# Altium schematic sheet EntradaCTLB50.SchDoc
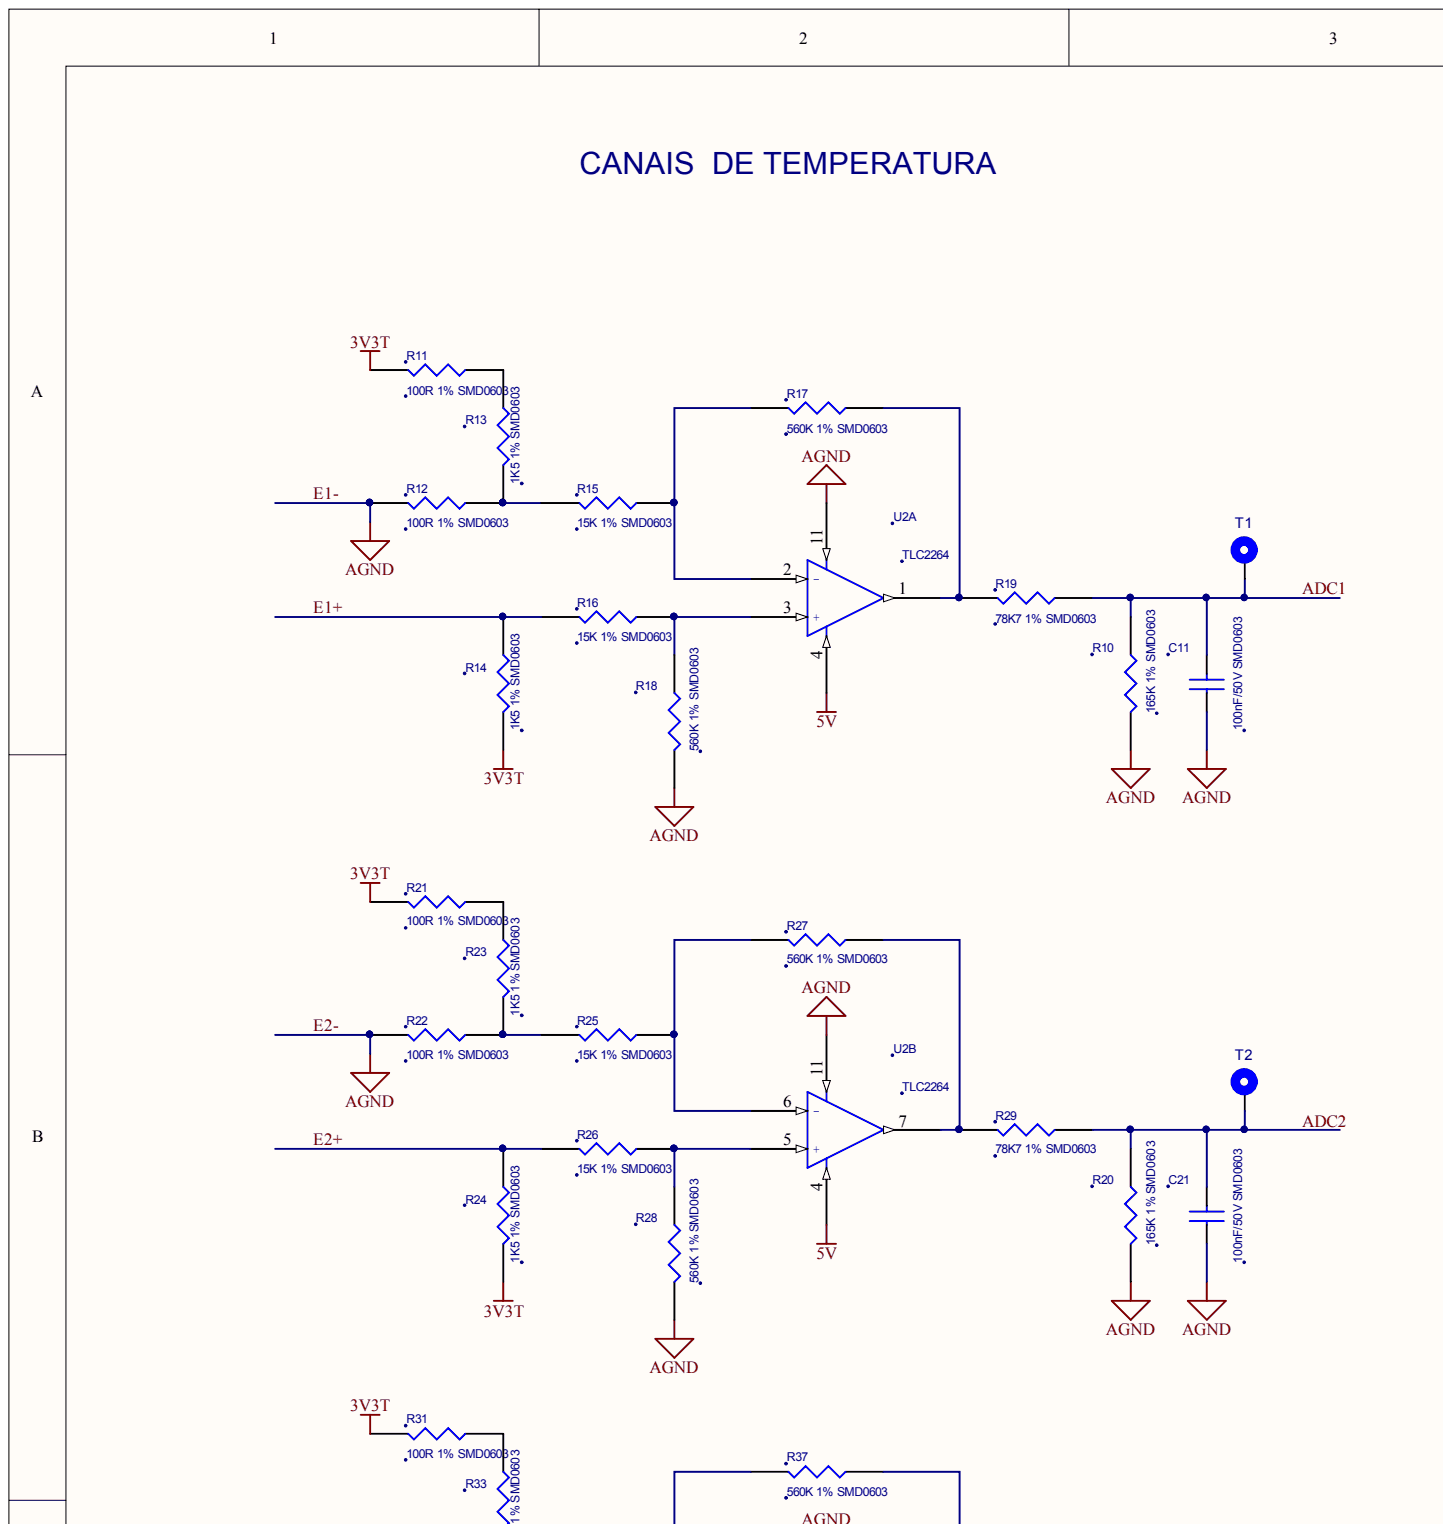
[diagram p0: EntradaCTLB50.SchDoc, top left]
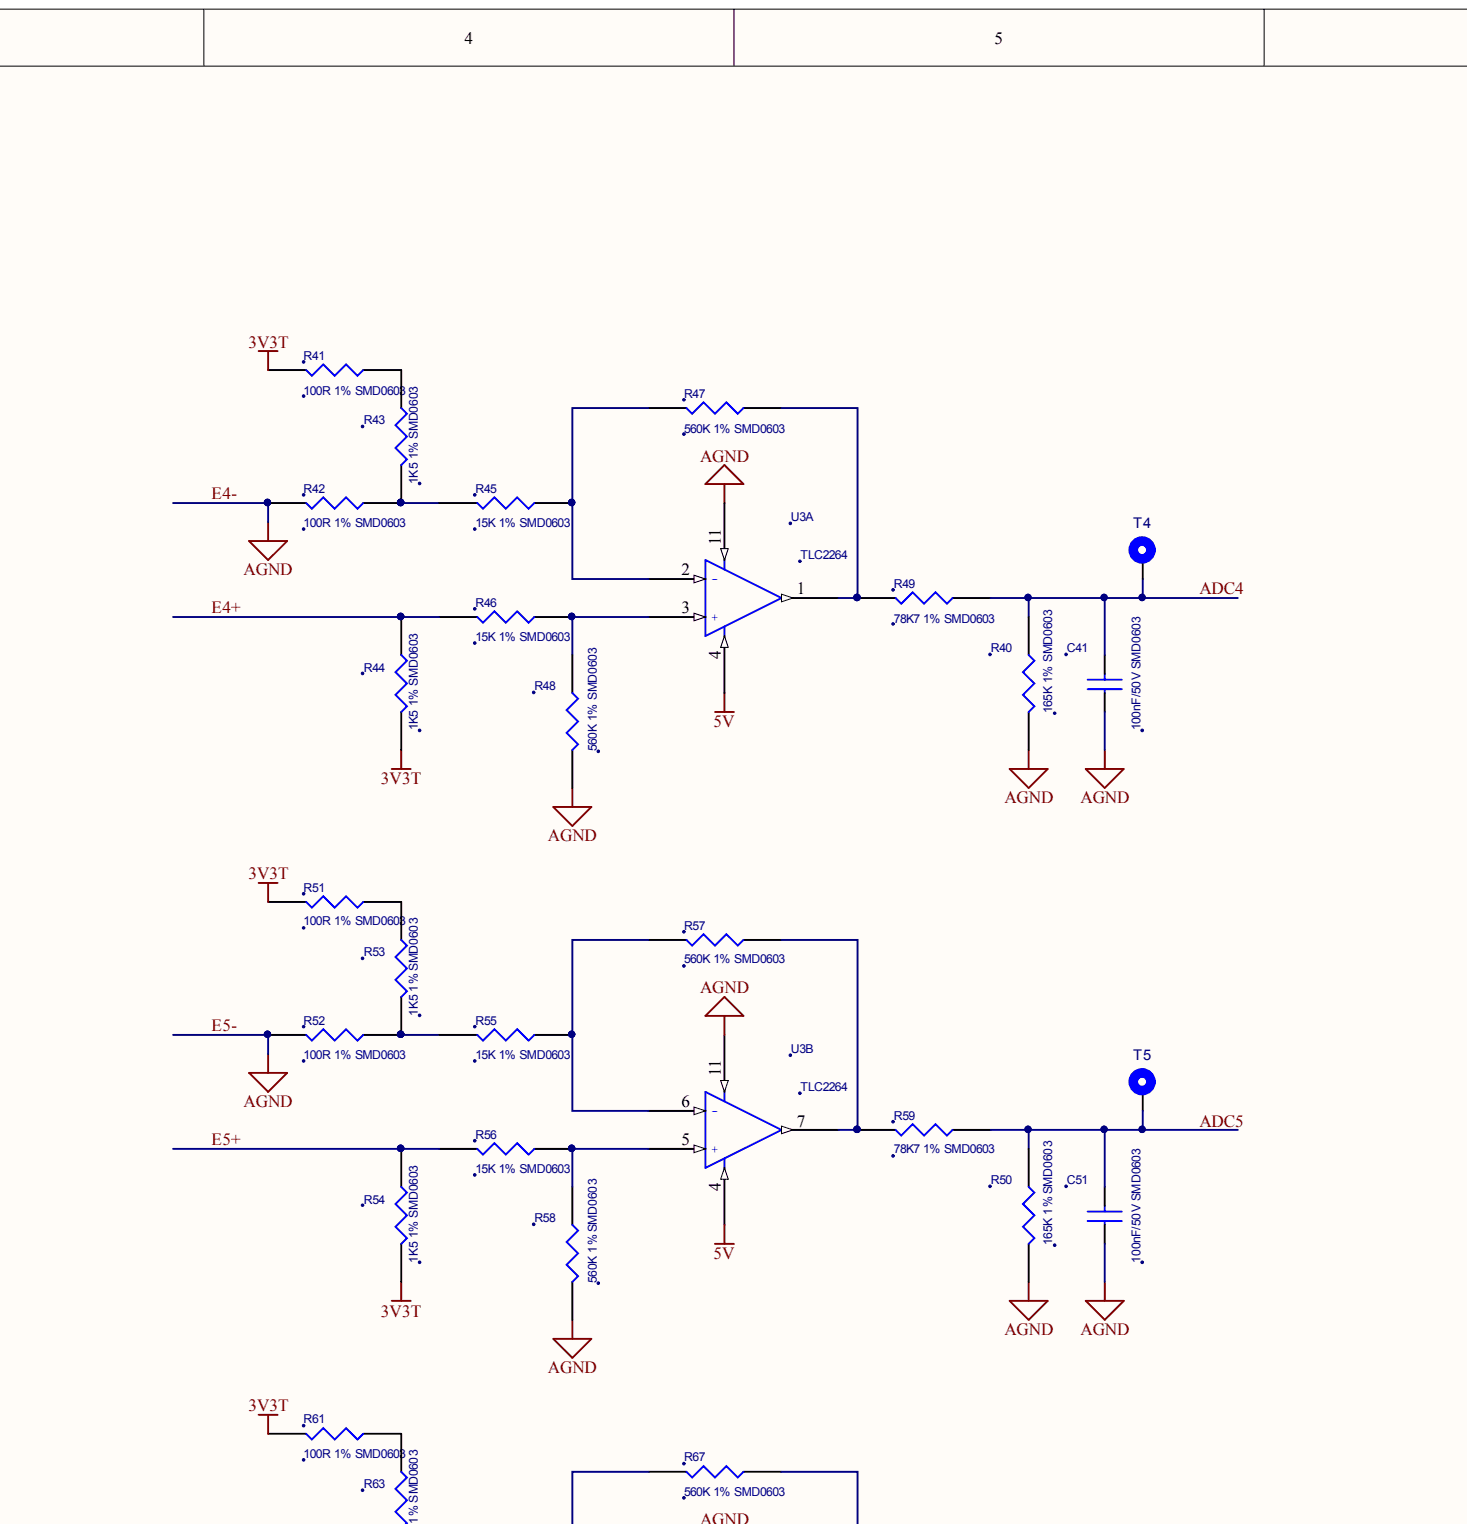
[diagram p1: EntradaCTLB50.SchDoc, top centre]
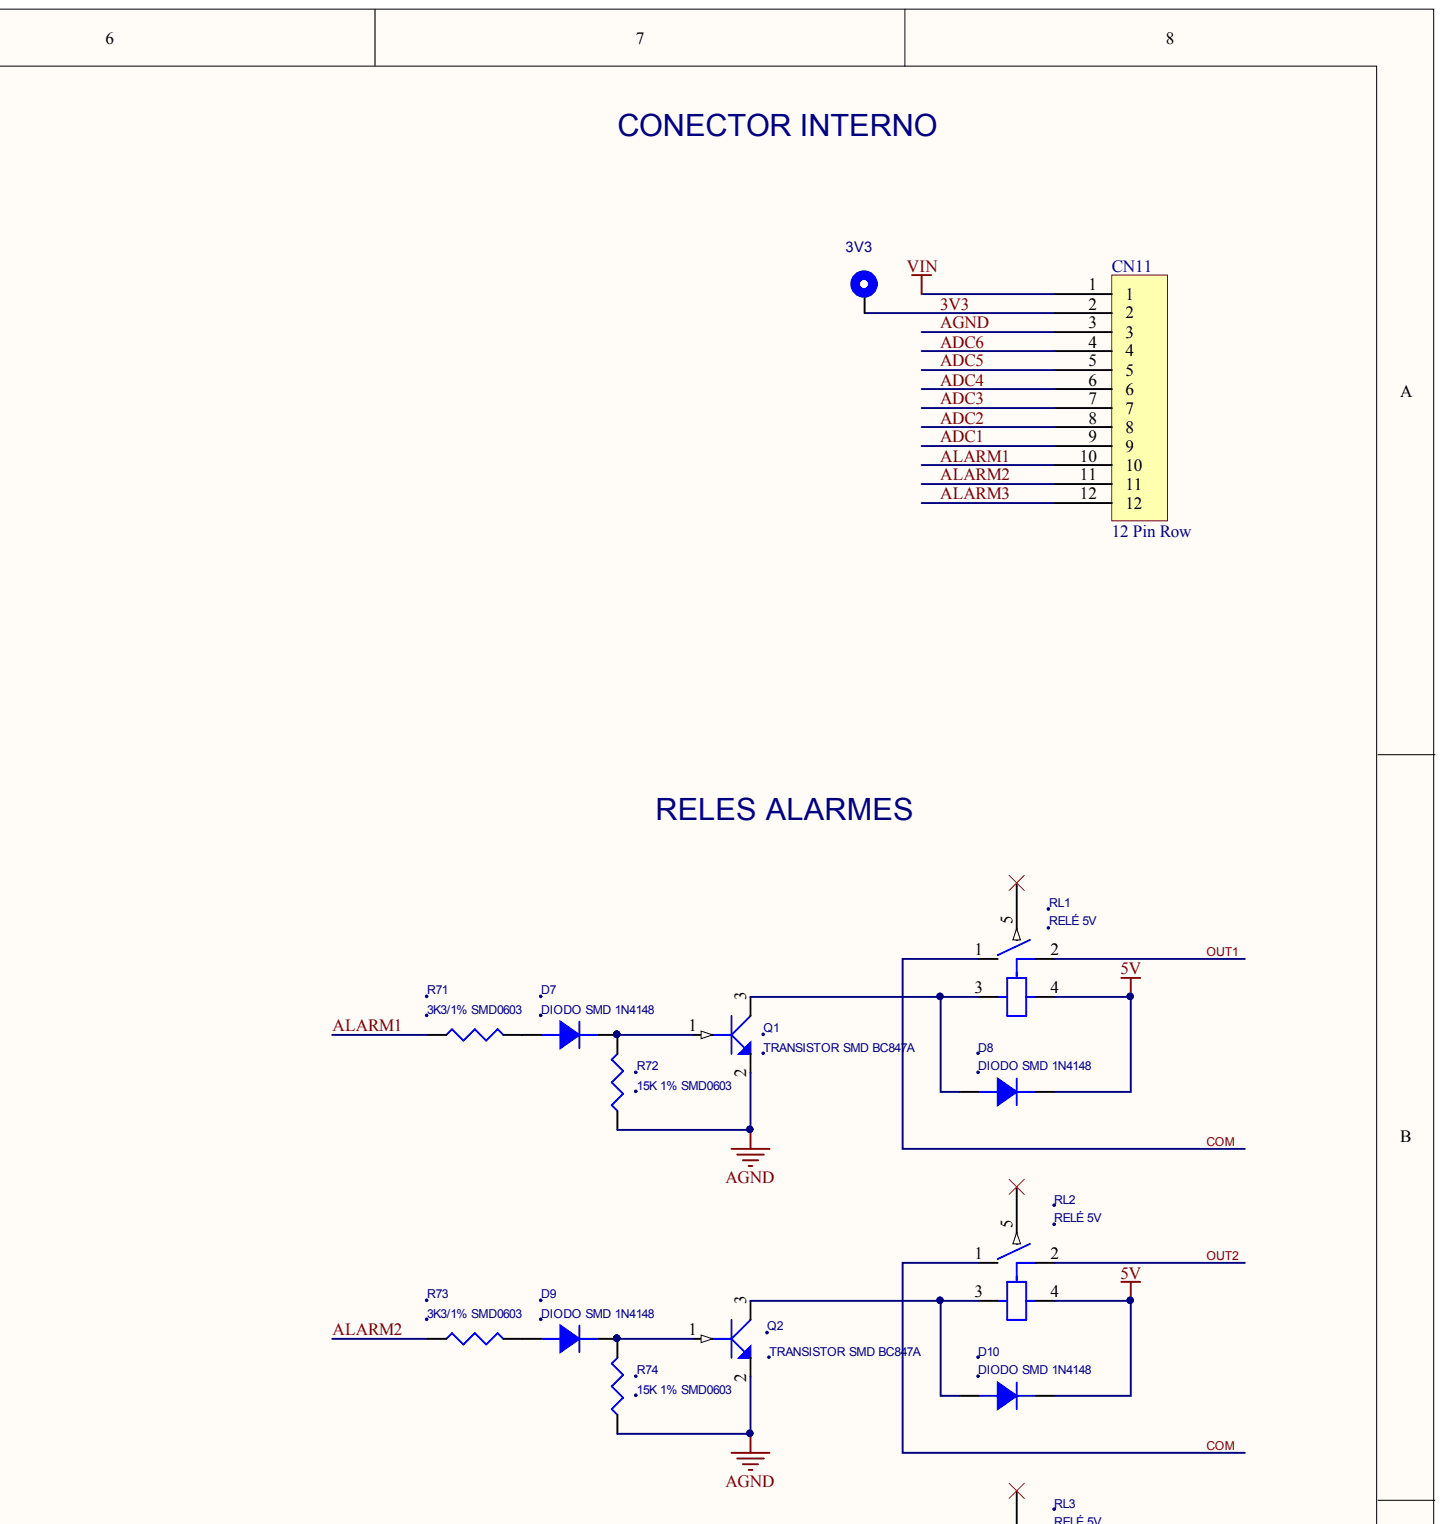
[diagram p2: EntradaCTLB50.SchDoc, top right]
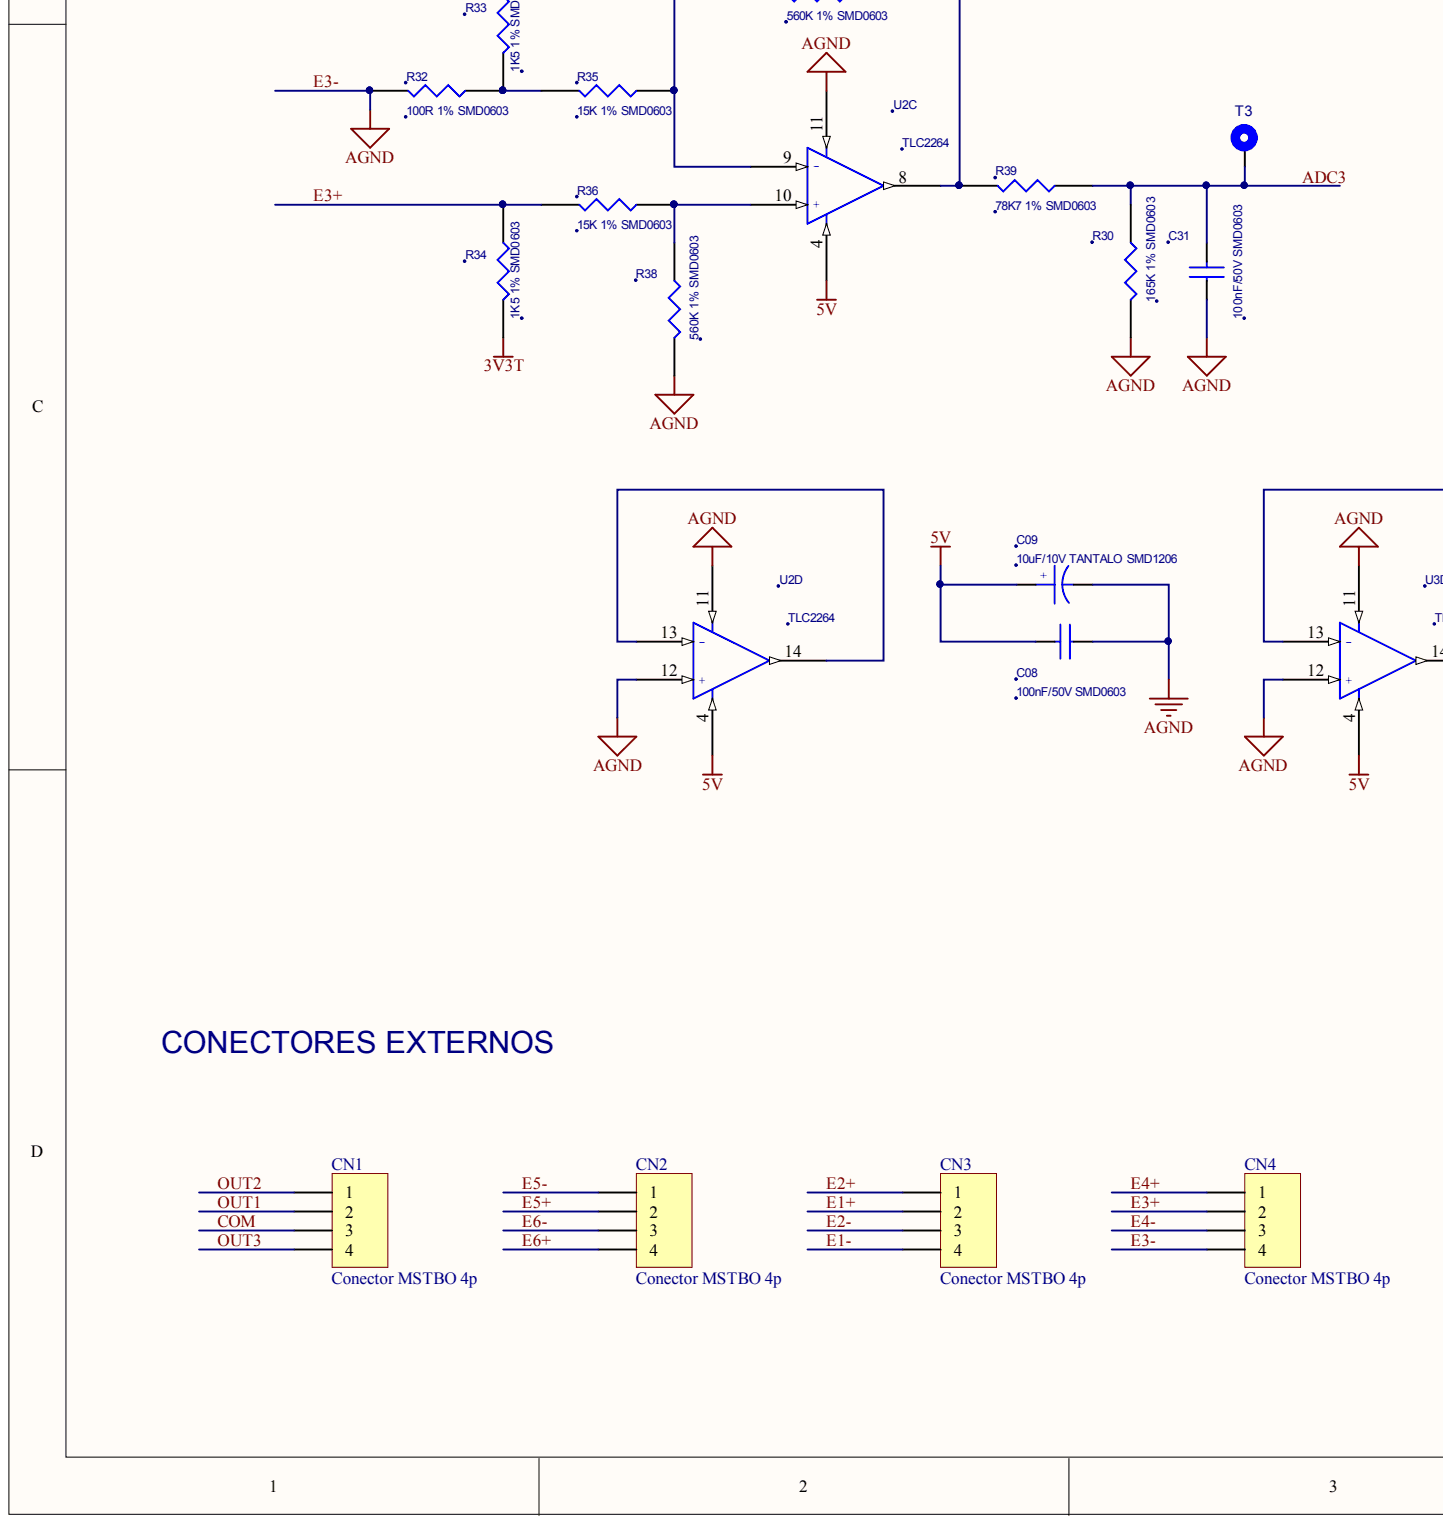
[diagram p3: EntradaCTLB50.SchDoc, bottom left]
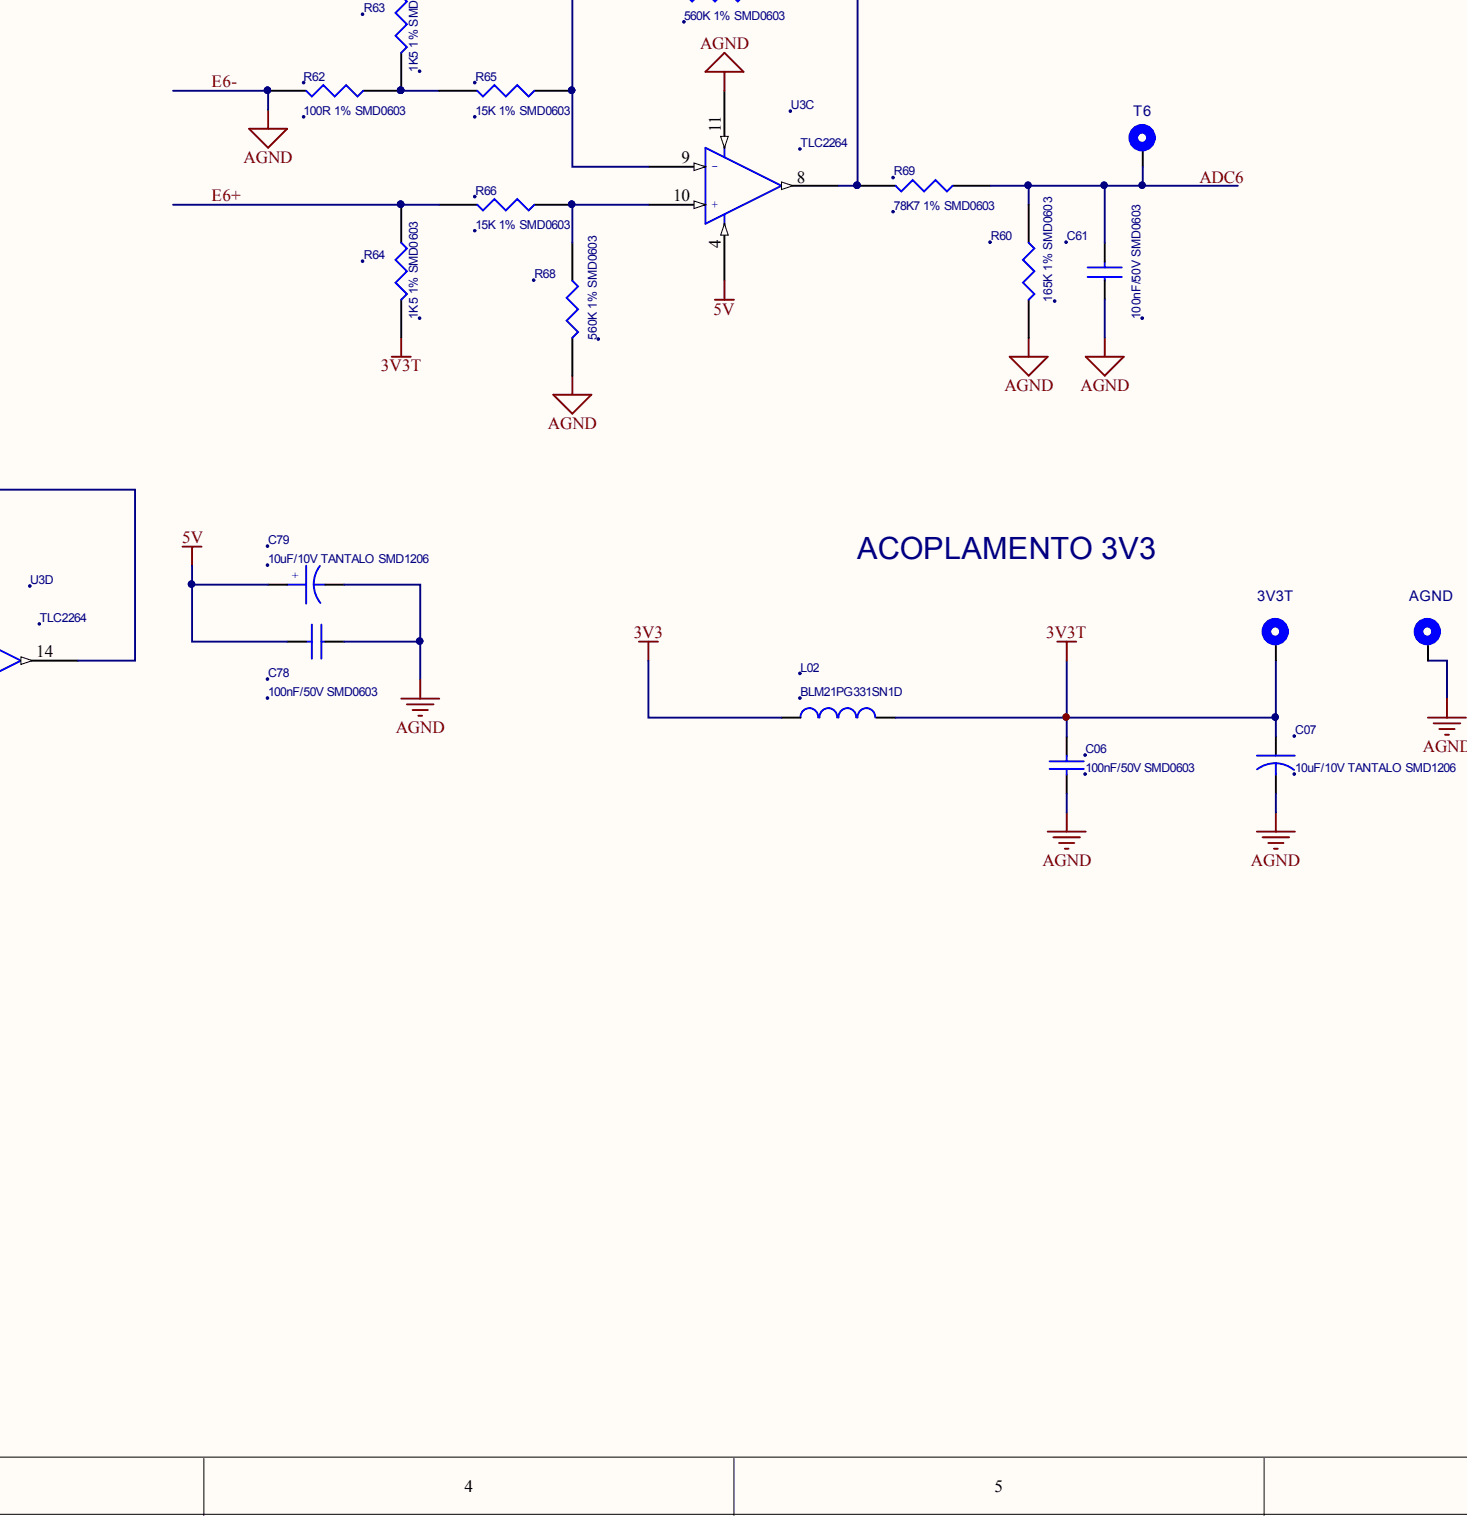
[diagram p4: EntradaCTLB50.SchDoc, bottom centre]
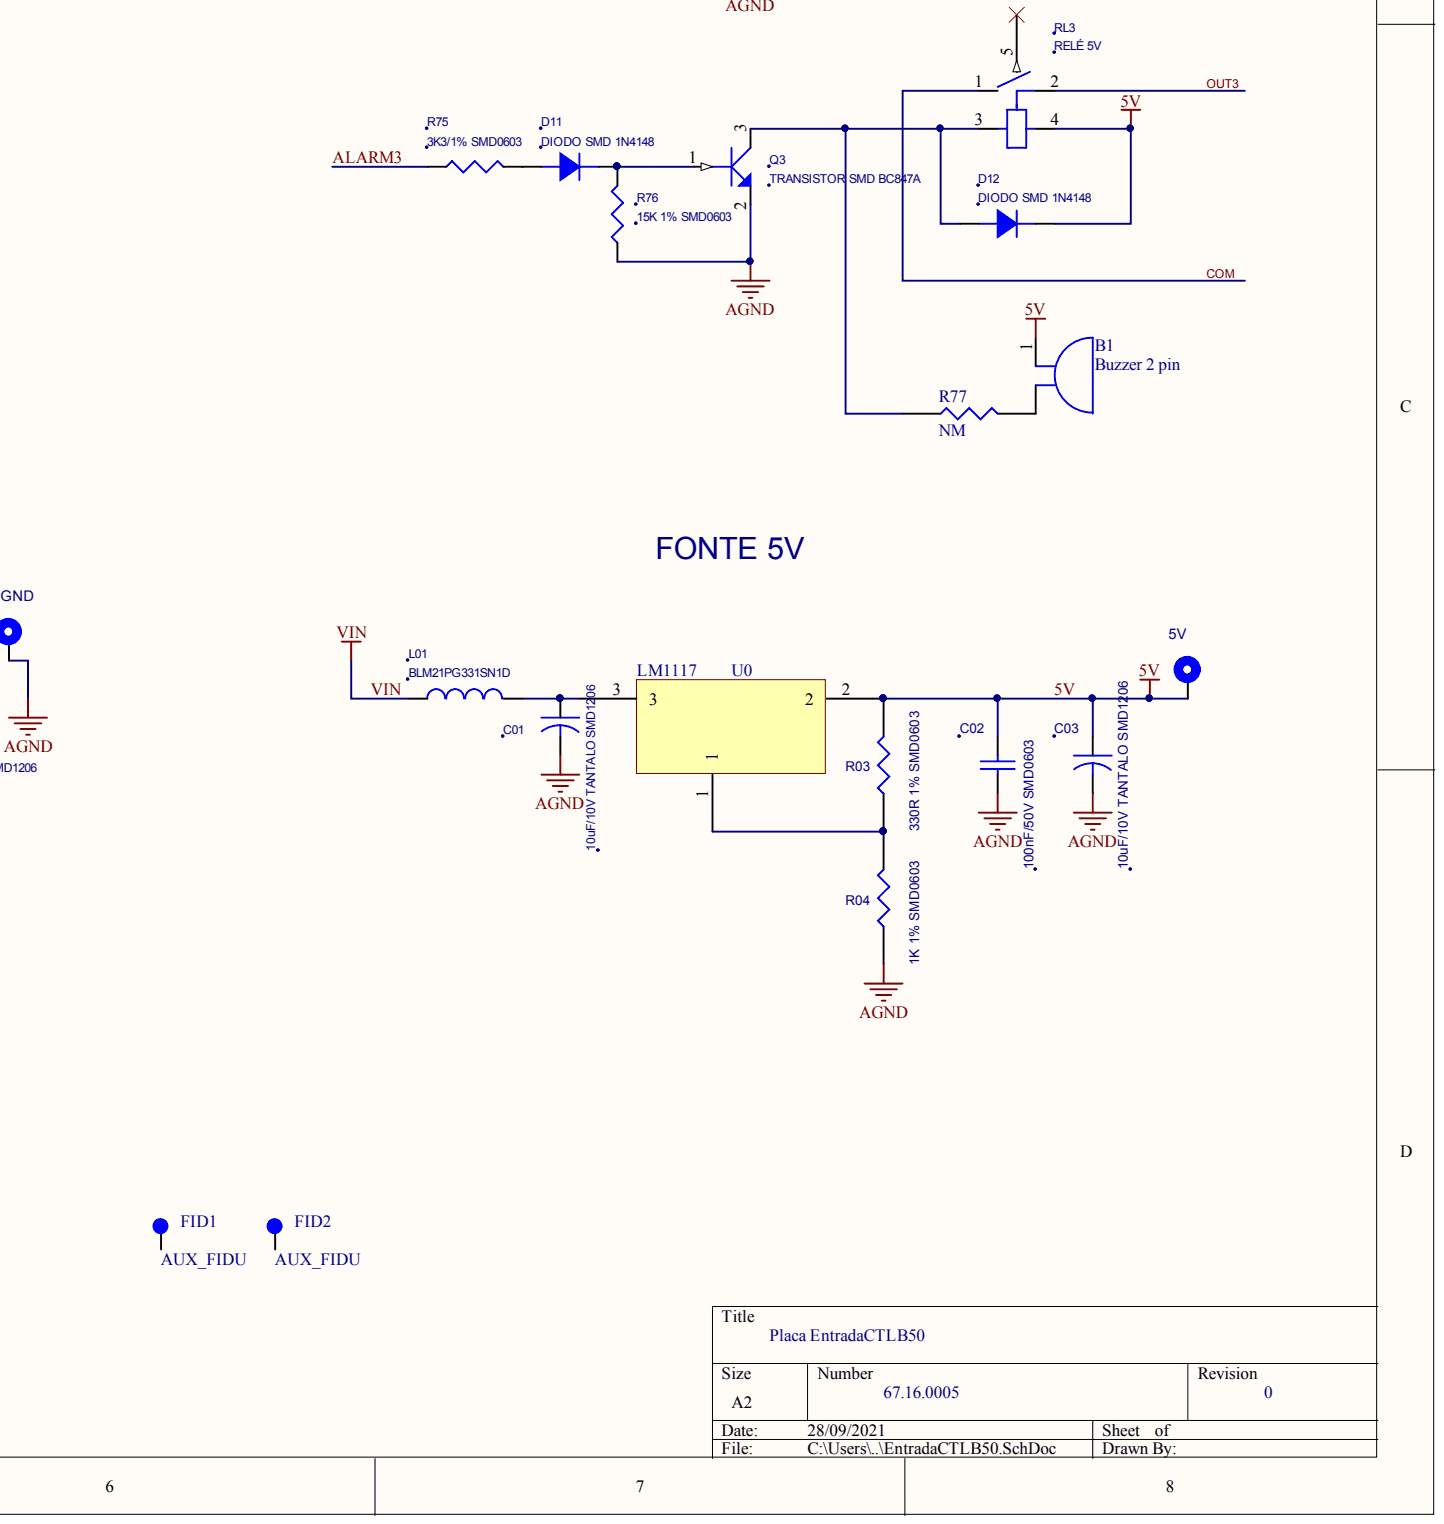
[diagram p5: EntradaCTLB50.SchDoc, bottom right]

## Parts
3V3  PT  [PTR31]  (value not drawn)
3V3T  PT  [PTR31]  (value not drawn)
5V  PT  [PTR31]  (value not drawn)
AGND  PT  [PTR31]  (value not drawn)
B1  Buzzer 2 pin  [Buzzer 5V d20mm]
C01  10uF/10V TANTALO SMD1206  [TANA]
C02  100nF/50V SMD0603  [0603]
C03  10uF/10V TANTALO SMD1206  [TANA]
C06  100nF/50V SMD0603  [0603]
C07  10uF/10V TANTALO SMD1206  [TANA]
C08  100nF/50V SMD0603  [0603]
C09  10uF/10V TANTALO SMD1206  [TANA]
C11  100nF/50V SMD0603  [0603]
C21  100nF/50V SMD0603  [0603]
C31  100nF/50V SMD0603  [0603]
C41  100nF/50V SMD0603  [0603]
C51  100nF/50V SMD0603  [0603]
C61  100nF/50V SMD0603  [0603]
C78  100nF/50V SMD0603  [0603]
C79  10uF/10V TANTALO SMD1206  [TANA]
CN1  Conector MSTBO 4p  [MSTBO-DIR]
CN2  Conector MSTBO 4p  [MSTBO-DIR]
CN3  Conector MSTBO 4p  [MSTBO-ESQ]
CN4  Conector MSTBO 4p  [MSTBO-ESQ]
CN11  12 Pin Row  [12 Pin Row]
D7  DIODO SMD 1N4148  [DIODOSMD]
D8  DIODO SMD 1N4148  [DIODOSMD]
D9  DIODO SMD 1N4148  [DIODOSMD]
D10  DIODO SMD 1N4148  [DIODOSMD]
D11  DIODO SMD 1N4148  [DIODOSMD]
D12  DIODO SMD 1N4148  [DIODOSMD]
FID1  AUX_FIDU  [AUX_FIDUCIAL]
FID2  AUX_FIDU  [AUX_FIDUCIAL]
L01  BLM21PG331SN1D  [BLM21]
L02  BLM21PG331SN1D  [BLM21]
Q1  TRANSISTOR SMD BC847A  [BC847A]
Q2  TRANSISTOR SMD BC847A  [bc847a]
Q3  TRANSISTOR SMD BC847A  [bc847a]
R03  330R 1% SMD0603  [0603]
R04  1K 1% SMD0603  [0603]
R10  165K 1% SMD0603  [0603]
R11  100R 1% SMD0603  [0603]
R12  100R 1% SMD0603  [0603]
R13  1K5 1% SMD0603  [0603]
R14  1K5 1% SMD0603  [0603]
R15  15K 1% SMD0603  [0603]
R16  15K 1% SMD0603  [0603]
R17  560K 1% SMD0603  [0603]
R18  560K 1% SMD0603  [0603]
R19  78K7 1% SMD0603  [0603]
R20  165K 1% SMD0603  [0603]
R21  100R 1% SMD0603  [0603]
R22  100R 1% SMD0603  [0603]
R23  1K5 1% SMD0603  [0603]
R24  1K5 1% SMD0603  [0603]
R25  15K 1% SMD0603  [0603]
R26  15K 1% SMD0603  [0603]
R27  560K 1% SMD0603  [0603]
R28  560K 1% SMD0603  [0603]
R29  78K7 1% SMD0603  [0603]
R30  165K 1% SMD0603  [0603]
R31  100R 1% SMD0603  [0603]
R32  100R 1% SMD0603  [0603]
R33  1K5 1% SMD0603  [0603]
R34  1K5 1% SMD0603  [0603]
R35  15K 1% SMD0603  [0603]
R36  15K 1% SMD0603  [0603]
R37  560K 1% SMD0603  [0603]
R38  560K 1% SMD0603  [0603]
R39  78K7 1% SMD0603  [0603]
R40  165K 1% SMD0603  [0603]
R41  100R 1% SMD0603  [0603]
R42  100R 1% SMD0603  [0603]
R43  1K5 1% SMD0603  [0603]
R44  1K5 1% SMD0603  [0603]
R45  15K 1% SMD0603  [0603]
R46  15K 1% SMD0603  [0603]
R47  560K 1% SMD0603  [0603]
R48  560K 1% SMD0603  [0603]
R49  78K7 1% SMD0603  [0603]
R50  165K 1% SMD0603  [0603]
R51  100R 1% SMD0603  [0603]
R52  100R 1% SMD0603  [0603]
R53  1K5 1% SMD0603  [0603]
R54  1K5 1% SMD0603  [0603]
R55  15K 1% SMD0603  [0603]
R56  15K 1% SMD0603  [0603]
R57  560K 1% SMD0603  [0603]
R58  560K 1% SMD0603  [0603]
R59  78K7 1% SMD0603  [0603]
R60  165K 1% SMD0603  [0603]
R61  100R 1% SMD0603  [0603]
R62  100R 1% SMD0603  [0603]
R63  1K5 1% SMD0603  [0603]
R64  1K5 1% SMD0603  [0603]
R65  15K 1% SMD0603  [0603]
R66  15K 1% SMD0603  [0603]
R67  560K 1% SMD0603  [0603]
R68  560K 1% SMD0603  [0603]
R69  78K7 1% SMD0603  [0603]
R71  3K3/1% SMD0603  [C0603]
R72  15K 1% SMD0603  [C0603]
R73  3K3/1% SMD0603  [C0603]
R74  15K 1% SMD0603  [C0603]
R75  3K3/1% SMD0603  [C0603]
R76  15K 1% SMD0603  [C0603]
R77  NM  [0603]
RL1  RELÉ 5V  [RELE2]
RL2  RELÉ 5V  [RELE2]
RL3  RELÉ 5V  [RELE2]
T1  PT  [PTSMD60]  (value not drawn)
T2  PT  [PTSMD60]  (value not drawn)
T3  PT  [PTSMD60]  (value not drawn)
T4  PT  [PTSMD60]  (value not drawn)
T5  PT  [PTSMD60]  (value not drawn)
T6  PT  [PTSMD60]  (value not drawn)
U0  LM1117  [SOT223G]
U2  TLC2264  [SOIC14]  (parts A,B,C,D)
U3  TLC2264  [SOIC14]  (parts A,B,C,D)

## Nets
3V3T: 3V3T.1 C06.1 C07.1 L02.2 R11.2 R14.2 R21.2 R24.2 R31.2 R34.2 R41.2 R44.2 R51.2 R54.2 R61.2 R64.2
3V3: 3V3.1 CN11.2 L02.1
5V: 5V.1 B1.1 C02.1 C03.1 C08.2 C09.1 C78.2 C79.1 D8.2 D10.2 D12.2 R03.2 RL1.4 RL2.4 RL3.4 U0.2 U2.4(5V) U3.4(5V)
ADC1: C11.2 CN11.9 R10.1 R19.1 T1.1
ADC2: C21.2 CN11.8 R20.1 R29.1 T2.1
ADC3: C31.2 CN11.7 R30.1 R39.1 T3.1
ADC4: C41.2 CN11.6 R40.1 R49.1 T4.1
ADC5: C51.2 CN11.5 R50.1 R59.1 T5.1
ADC6: C61.2 CN11.4 R60.1 R69.1 T6.1
AGND: AGND.1 C01.2 C02.2 C03.2 C06.2 C07.2 C08.1 C09.2 C11.1 C21.1 C31.1 C41.1 C51.1 C61.1 C78.1 C79.2 CN2.1 CN2.3 CN3.3 CN3.4 CN4.3 CN4.4 CN11.3 Q1.2 Q2.2 Q3.2 R04.1 R10.2 R12.2 R18.2 R20.2 R22.2 R28.2 R30.2 R32.2 R38.2 R40.2 R42.2 R48.2 R50.2 R52.2 R58.2 R60.2 R62.2 R68.2 R72.1 R74.1 R76.1 U2.11 U2.12(+) U3.11 U3.12(+)
ALARM1: CN11.10 R71.1
ALARM2: CN11.11 R73.1
ALARM3: CN11.12 R75.1
COM: CN1.3 RL1.1 RL2.1 RL3.1
E1+: CN3.2 R14.1 R16.2
E2+: CN3.1 R24.1 R26.2
E3+: CN4.2 R34.1 R36.2
E4+: CN4.1 R44.1 R46.2
E5+: CN2.2 R54.1 R56.2
E6+: CN2.4 R64.1 R66.2
OUT1: CN1.2 RL1.2
OUT2: CN1.1 RL2.2
OUT3: CN1.4 RL3.2
VIN: CN11.1 L01.1
NetB1_2: B1.2 R77.2
NetC01_1: C01.1 L01.2 U0.3
NetD7_1: D7.1 R71.2
NetD7_2: D7.2 Q1.1 R72.2
NetD8_1: D8.1 Q1.3 RL1.3
NetD9_1: D9.1 R73.2
NetD9_2: D9.2 Q2.1 R74.2
NetD10_1: D10.1 Q2.3 RL2.3
NetD11_1: D11.1 R75.2
NetD11_2: D11.2 Q3.1 R76.2
NetD12_1: D12.1 Q3.3 R77.1 RL3.3
NetR03_1: R03.1 R04.2 U0.1
NetR11_1: R11.1 R13.1
NetR12_1: R12.1 R13.2 R15.2
NetR15_1: R15.1 R17.2 U2.2(-)
NetR16_1: R16.1 R18.1 U2.3(+)
NetR17_1: R17.1 R19.2 U2.1
NetR21_1: R21.1 R23.1
NetR22_1: R22.1 R23.2 R25.2
NetR25_1: R25.1 R27.2 U2.6(-)
NetR26_1: R26.1 R28.1 U2.5(+)
NetR27_1: R27.1 R29.2 U2.7
NetR31_1: R31.1 R33.1
NetR32_1: R32.1 R33.2 R35.2
NetR35_1: R35.1 R37.2 U2.9(-)
NetR36_1: R36.1 R38.1 U2.10(+)
NetR37_1: R37.1 R39.2 U2.8
NetR41_1: R41.1 R43.1
NetR42_1: R42.1 R43.2 R45.2
NetR45_1: R45.1 R47.2 U3.2(-)
NetR46_1: R46.1 R48.1 U3.3(+)
NetR47_1: R47.1 R49.2 U3.1
NetR51_1: R51.1 R53.1
NetR52_1: R52.1 R53.2 R55.2
NetR55_1: R55.1 R57.2 U3.6(-)
NetR56_1: R56.1 R58.1 U3.5(+)
NetR57_1: R57.1 R59.2 U3.7
NetR61_1: R61.1 R63.1
NetR62_1: R62.1 R63.2 R65.2
NetR65_1: R65.1 R67.2 U3.9(-)
NetR66_1: R66.1 R68.1 U3.10(+)
NetR67_1: R67.1 R69.2 U3.8
NetU2_13: U2.13(-) U2.14
NetU3_13: U3.13(-) U3.14

Not connected: FID1 1; FID2 1; RL1 5; RL2 5; RL3 5

## Library data of the parts
3V3 3V3T 5V AGND T1-T6 = AUX_PT: PONTO DE TESTE SMD; Component Kind: Standard; Library Name: AUXILIAR_SYM.LIB
C01 = C: Component Kind: Standard
C02 C06 = CAP: Capacitor; Component Kind: Standard
C03 C07 = CAPACITOR: Component Kind: Standard; Description: Capacitor
  C03: : SMD 1206
C08 C11 C21 C31 C41 C51 C61 C78 = CAP NP: Component Kind: Standard
C09 C79 = CAPACITOR POL_0: PN: TAJA106K010RNJ; TYPE: 1206; COD CIGAM: 09.12.0001; Component Kind: Standard
D7 = DIODE: Component Kind: Standard
D8 = 1N4148_3: Component Kind: Standard
D9-D12 = DIODE_Dup1: Component Kind: Standard
FID1 FID2 = AUX_FIDU: FIDUCIAL; Component Kind: Standard; Library Name: AUXILIAR_SYM.LIB
L01 L02 = INDUCTOR: Component Kind: Standard
Q1-Q3 = BC847AL: Component Kind: Standard
R03 R04 R10-R69 R77 = RES1: Component Kind: Standard
R71 = R: Component Kind: Standard
R72 = R_Dup2: Component Kind: Standard
R73-R76 = R_Dup1: Component Kind: Standard
RL1-RL3 = REL_0: Component Kind: Standard
U0 = LM 2574 - 5V: Text Field1: 100; Text Field2: 50; Component Kind: Standard
U2 U3 = LM324: Component Kind: Standard
B1 = Buzzer 2 pin: Component Kind: Standard; Library Name: SCH - Buzzer 2 pin.SCHLIB
CN1-CN4 = Conector MSTBO 4p: Component Kind: Standard; Library Name: md30e-1.SCHLIB
CN11 = 12 Pin Row: Component Kind: Standard; Library Name: SCH - CONNECTORS - 12 PIN.SchLib
